# Altium schematic sheet FPGA_config.SchDoc
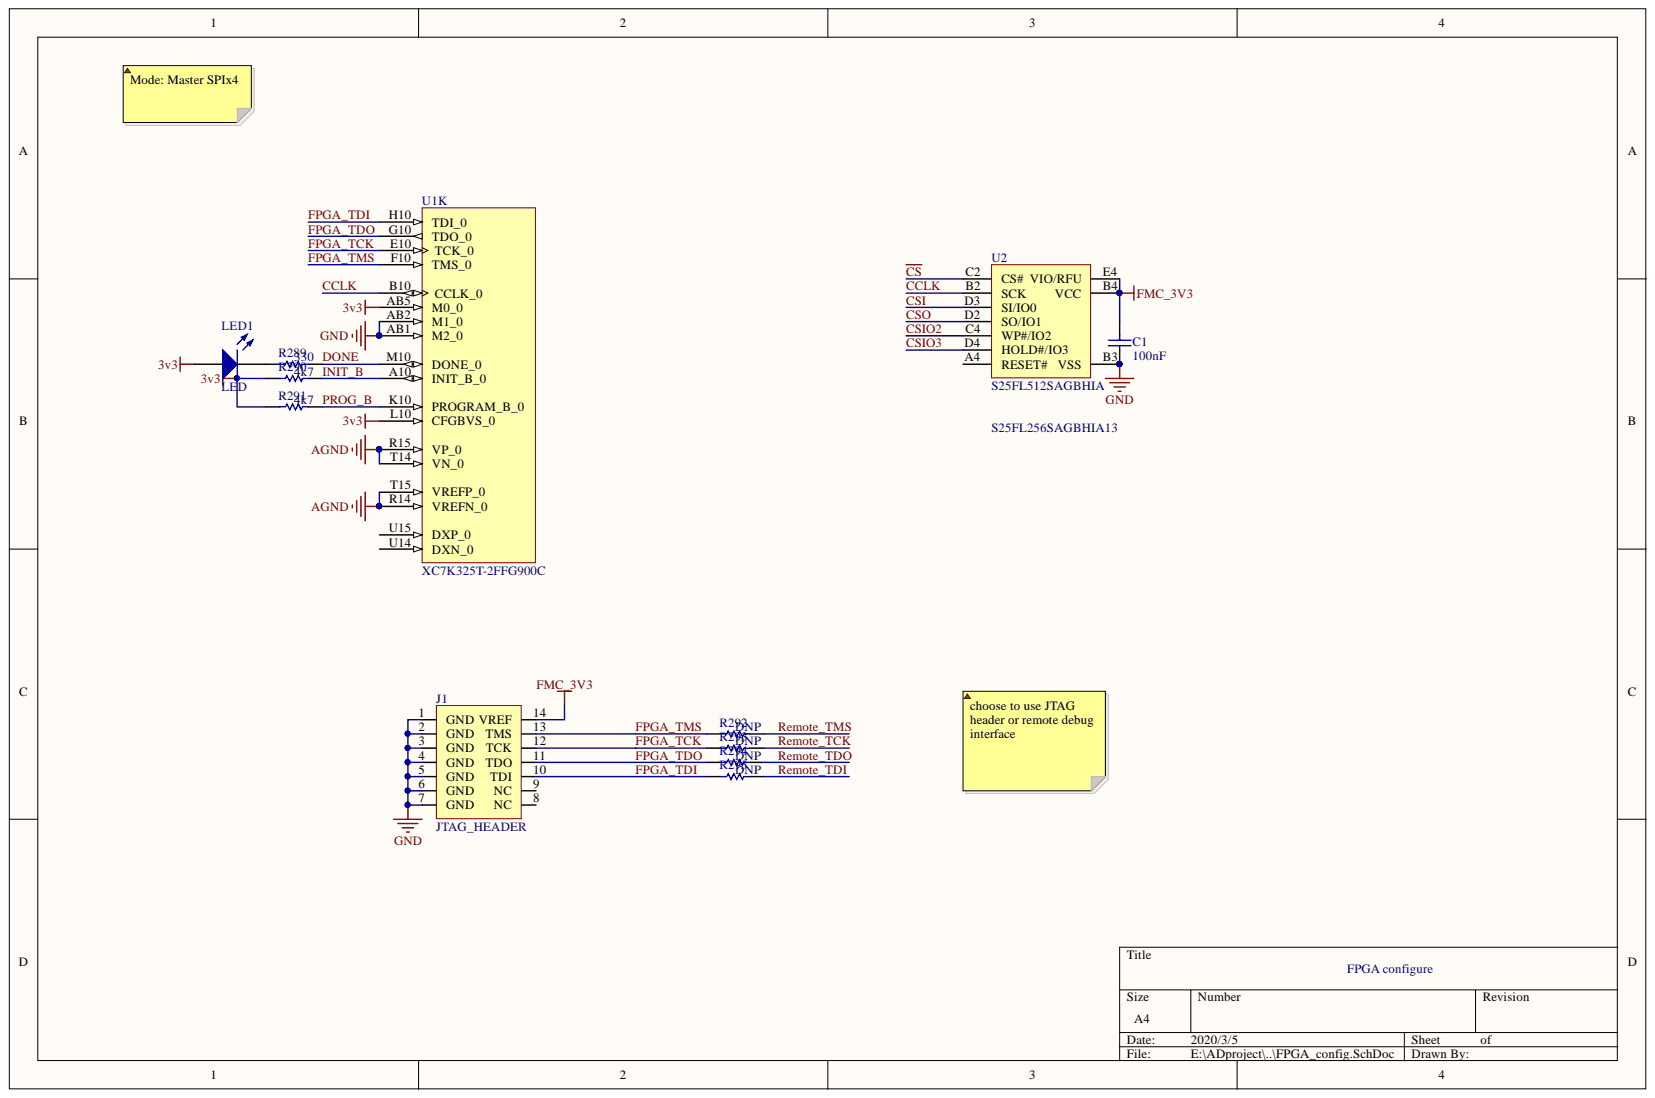
[diagram p0: FPGA_config.SchDoc]

## Parts
C1  value 100nF  [0402]  (hidden comment: Cap)
J1  JTAG_HEADER  [HEADER_14]
LED1  LED  [led_0603r]
R289  value 330  [0402]
R290  value 4k7  [0402]
R291  value 4k7  [0402]
R292  value DNP  [0402]
R293  value DNP  [0402]
R294  value DNP  [0402]
R295  value DNP  [0402]
U1  XC7K325T-2FFG900C  [FFG900]  (part K)
U2  S25FL512SAGBHIA  [bga24x5]

## Nets
3v3: LED1.2 R290.1 R291.1 U1.AB5(M0_0) U1.L10(CFGBVS_0)
AGND: U1.R14(VREFN_0) U1.R15(VP_0) U1.T14(VN_0) U1.T15(VREFP_0)
FMC_3V3: C1.1 J1.14(VREF) U2.B4(VCC) U2.E4(VIO/RFU)
GND: C1.2 J1.1(GND) J1.2(GND) J1.3(GND) J1.4(GND) J1.5(GND) J1.6(GND) J1.7(GND) U1.AB1(M2_0) U1.AB2(M1_0) U2.B3(VSS)
~{CS}: U2.C2(CS#)
CCLK: U1.B10(CCLK_0) U2.B2(SCK)
CSI: U2.D3(SI/IO0)
CSIO2: U2.C4(WP#/IO2)
CSIO3: U2.D4(HOLD#/IO3)
CSO: U2.D2(SO/IO1)
DONE: R289.2 U1.M10(DONE_0)
FPGA_TCK: J1.12(TCK) R293.1 U1.E10(TCK_0)
FPGA_TDI: J1.10(TDI) R295.1 U1.H10(TDI_0)
FPGA_TDO: J1.11(TDO) R294.1 U1.G10(TDO_0)
FPGA_TMS: J1.13(TMS) R292.1 U1.F10(TMS_0)
INIT_B: R290.2 U1.A10(INIT_B_0)
PROG_B: R291.2 U1.K10(PROGRAM_B_0)
Remote_TCK: R293.2
Remote_TDI: R295.2
Remote_TDO: R294.2
Remote_TMS: R292.2
NetLED1_1: LED1.1 R289.1

Not connected: J1 8(NC) 9(NC); U1 U14(DXN_0) U15(DXP_0); U2 A4(RESET#)

## Library data of the parts
C1 = Cap: Capacitor; Component Kind: Standard; Library Name: Miscellaneous Devices.IntLib; Signal Integrity: Cap; Simulation: CAP; Publisher: Altium Limited
R289-R295 = CMP-1012-00454-1: Case-EIA: 0603; Case-Metric: 1608; Power: 0.1 W; Tolerance: 1 %; Component Kind: Standard; Library Name: Altium Content Vault; Technology: SMT
U1 = CMP-0361-00090-2: Kintex-7 FPGA, 500 User I/Os, 16 GTX, 900-Ball BGA, Speed Grade 2, Commerical Grade, Pb-Free; Manufacturer: Xilinx; GTX: 16; SelectIO HP: 150; SelectIO HR: 350; Speed Grade: -2; Code_JEDEC: MS-034-AAN-1; Component Kind: Standard; ComponentLink1Description: Datasheet; ComponentLink1URL: http://www.xilinx.com/support/documentation/data_sheets/ds180_7Series_Overview.pdf; ComponentLink2Description: Package Specification; ComponentLink2URL: http://www.xilinx.com/support/documentation/user_guides/ug865-Zynq-7000-Pkg-Pinout.pdf; DatasheetVersion: v1.12; Generic Part Number: XC7K325T; Library Name: Altium Content Vault; Mounting Technology: Surface Mount; PackageDescription: Flip-Chip BGA, Body 31 x 31 mm, 1.0 mm Pitch; PackageReference: FFG900; PackageVersion: Rev 1.1; PartId: 1; PartNumber: XC7K325T-2FFG900C; RoHS: Pb-Free
U2 = S25FL512SAGBHIA: 512Mbit SPI flash, with reset and Vio; Component Kind: Standard; Library Name: general.SchLib
J1 = JTAG_HEADER: Component Kind: Standard; Library Name: LS1_full_size.SCHLIB
LED1 = LED: Component Kind: Standard; Library Name: general.SchLib
